# Altium schematic sheet port.SchDoc
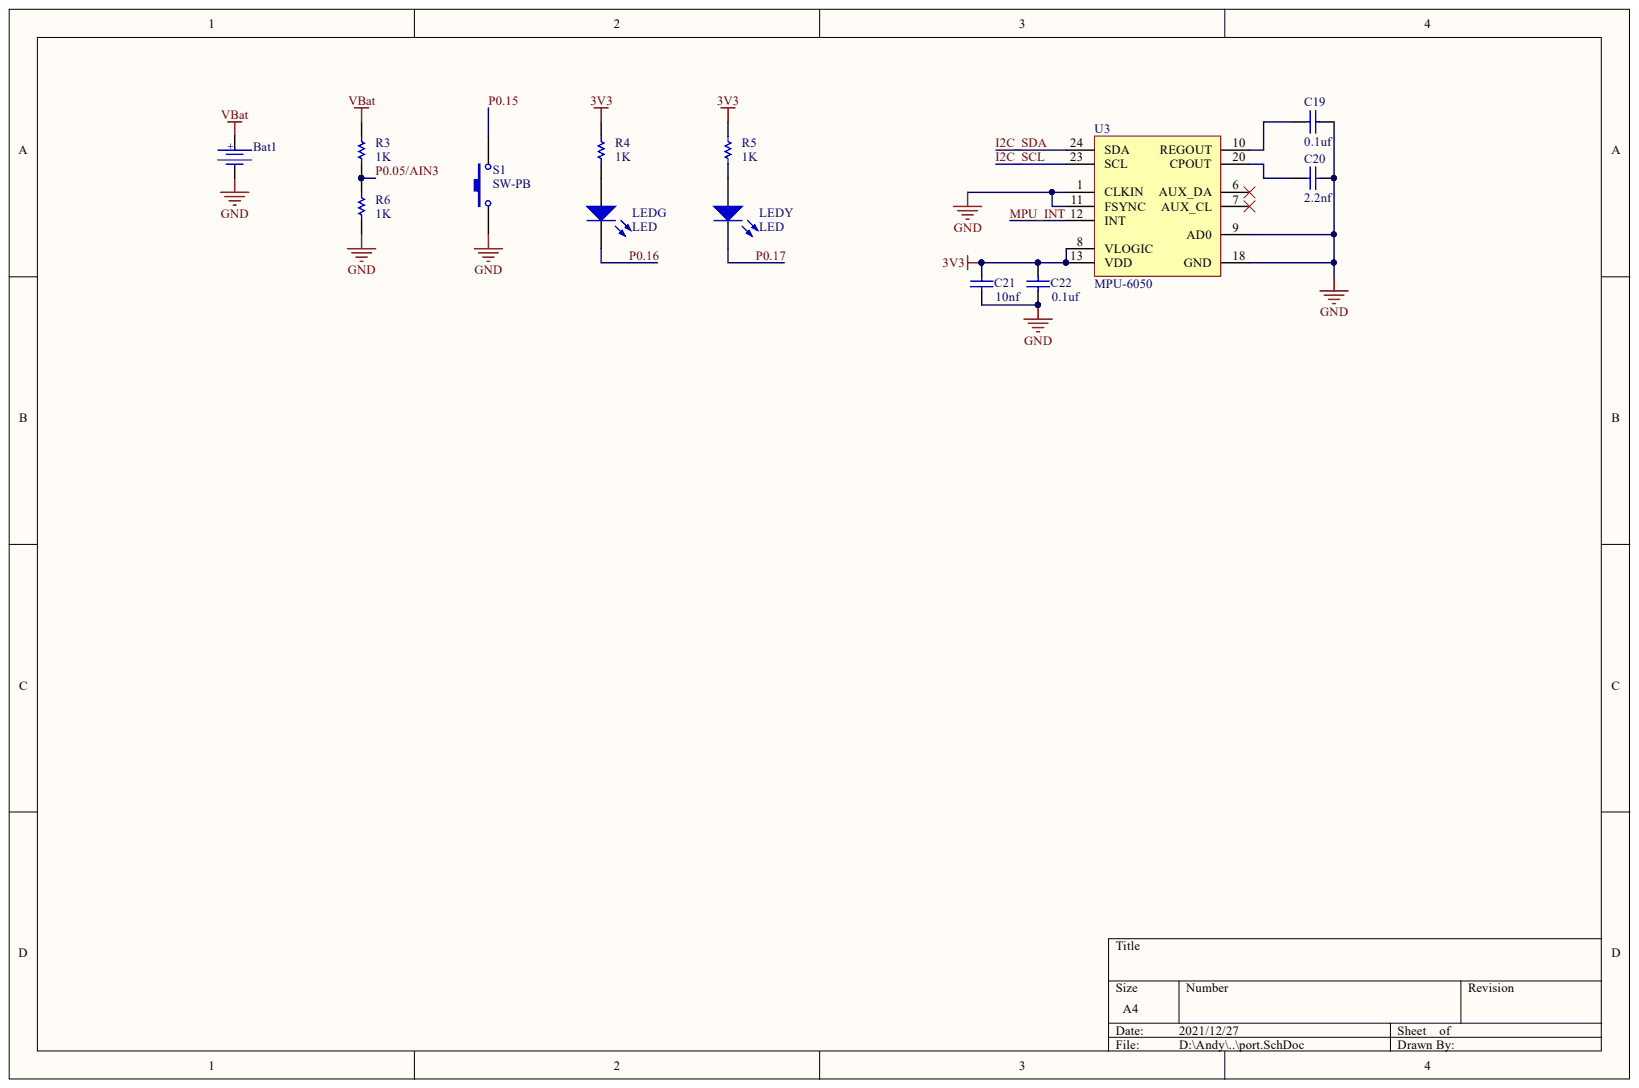
[diagram p0: port.SchDoc]

## Parts
Bat1  Bat Holder CR2032  [HDR1X2]  (value not drawn)
C19  value 0.1uf  [C0603]  (hidden comment: Cap Semi)
C20  value 2.2nf  [C0603]  (hidden comment: Cap Semi)
C21  value 10nf  [C0603]  (hidden comment: Cap Semi)
C22  value 0.1uf  [C0603]  (hidden comment: Cap Semi)
LEDG  LED  [0603]
LEDY  LED  [0603]
R3  value 1K  [R0603-M]  (hidden comment: Resistors SMD)
R4  value 1K  [R0603-M]  (hidden comment: Resistors SMD)
R5  value 1K  [R0603-M]  (hidden comment: Resistors SMD)
R6  value 1K  [R0603-M]  (hidden comment: Resistors SMD)
S1  SW-PB  [SWITCH_SMD_4x3_DHT-1185]
U3  MPU-6050  [MPU-6050]

## Nets
3V3: C21.2 C22.2 R4.2 R5.2 U3.8(VLOGIC) U3.13(VDD)
GND: Bat1.2 C19.1 C20.1 C21.1 C22.1 R6.1 S1.1 U3.1(CLKIN) U3.9(AD0) U3.11(FSYNC) U3.18(GND)
VBAT: Bat1.1 R3.2
I2C_SCL: U3.23(SCL)
I2C_SDA: U3.24(SDA)
MPU_INT: U3.12(INT)
P0.05/AIN3: R3.1 R6.2
P0.15: S1.2
P0.16: LEDG.2
P0.17: LEDY.2
NetC19_2: C19.2 U3.10(REGOUT)
NetC20_2: C20.2 U3.20(CPOUT)
NetLEDG_1: LEDG.1 R4.1
NetLEDY_1: LEDY.1 R5.1

Not connected: U3 6(AUX_DA) 7(AUX_CL)

## Library data of the parts
Bat1 = (no symbol name): Bat Holder CR2032 SMD; 2nd source part number: 165-0693; Manufacturer: Comfortable; Manufacturer Part Number: BC-2001; Artikkelnr Noca: 55089011; Artikkelnr Simpro: N.A.; 2nd source Supplier: Farnell; Component Kind: Standard; Datasheet: \\hizz\pro\app9717\Datasheet\connectores\Comfortable\BC-2001.pdf; Footprint Doc: CR2032_HOLDER_SMD; LatestRevisionDate: 2016-04-21; Library Name: Mylibrary.IntLib; Note: Bat Holder CR2032; Part Number: Bat Holder CR2032 SMD; Pin Count: 3; Published: 2009-12-12; Supplier: Cabcon; Supplier part number: BC-2001/T & R; Value: Bat Holder CR2032; Publisher: Nordic Semiconductor
C19-C22 = Cap Semi: Capacitor (Semiconductor SIM Model); Component Kind: Standard; LatestRevisionDate: 29-May-2009; LatestRevisionNote: IPC-7351 Footprint Added.; Library Name: Mylibrary.IntLib; PackageDescription: Chip Capacitor; PackageReference: C1206; Published: 8-Jun-2000; Signal Integrity: Cap Semi; Simulation: CAP; Publisher: Altium Limited
LEDG LEDY = LED: Typical INFRARED GaAs LED; Component Kind: Standard; LatestRevisionDate: 08-Jul-2005; LatestRevisionNote: Mech Layer 1 removed.; Library Name: Mylibrary.IntLib; PackageDescription: LED; 2 Leads; PackageReference: LED-0; Published: 8-Jun-2000; Simulation: LED0; Publisher: Altium Limited
R3-R6 = Resistors SMD: Resistor; Component Kind: Standard; Library Name: Mylibrary.IntLib; Signal Integrity: Res; Simulation: RESISTOR
S1 = SW-PB: Switch; Component Kind: Standard; LatestRevisionDate: 17-Jul-2002; LatestRevisionNote: Re-released for DXP Platform.; Library Name: Mylibrary.IntLib; PackageDescription: Switch; 2 Leads; PackageReference: SPST-2; Published: 24-Mar-1999; Publisher: Altium Limited
U3 = MPU-6050: Component Kind: Standard; Library Name: Mylibrary.IntLib
